# Altium schematic sheet SENSOR_Flash_RAM.SchDoc(SENSOR_Flash_RAM)
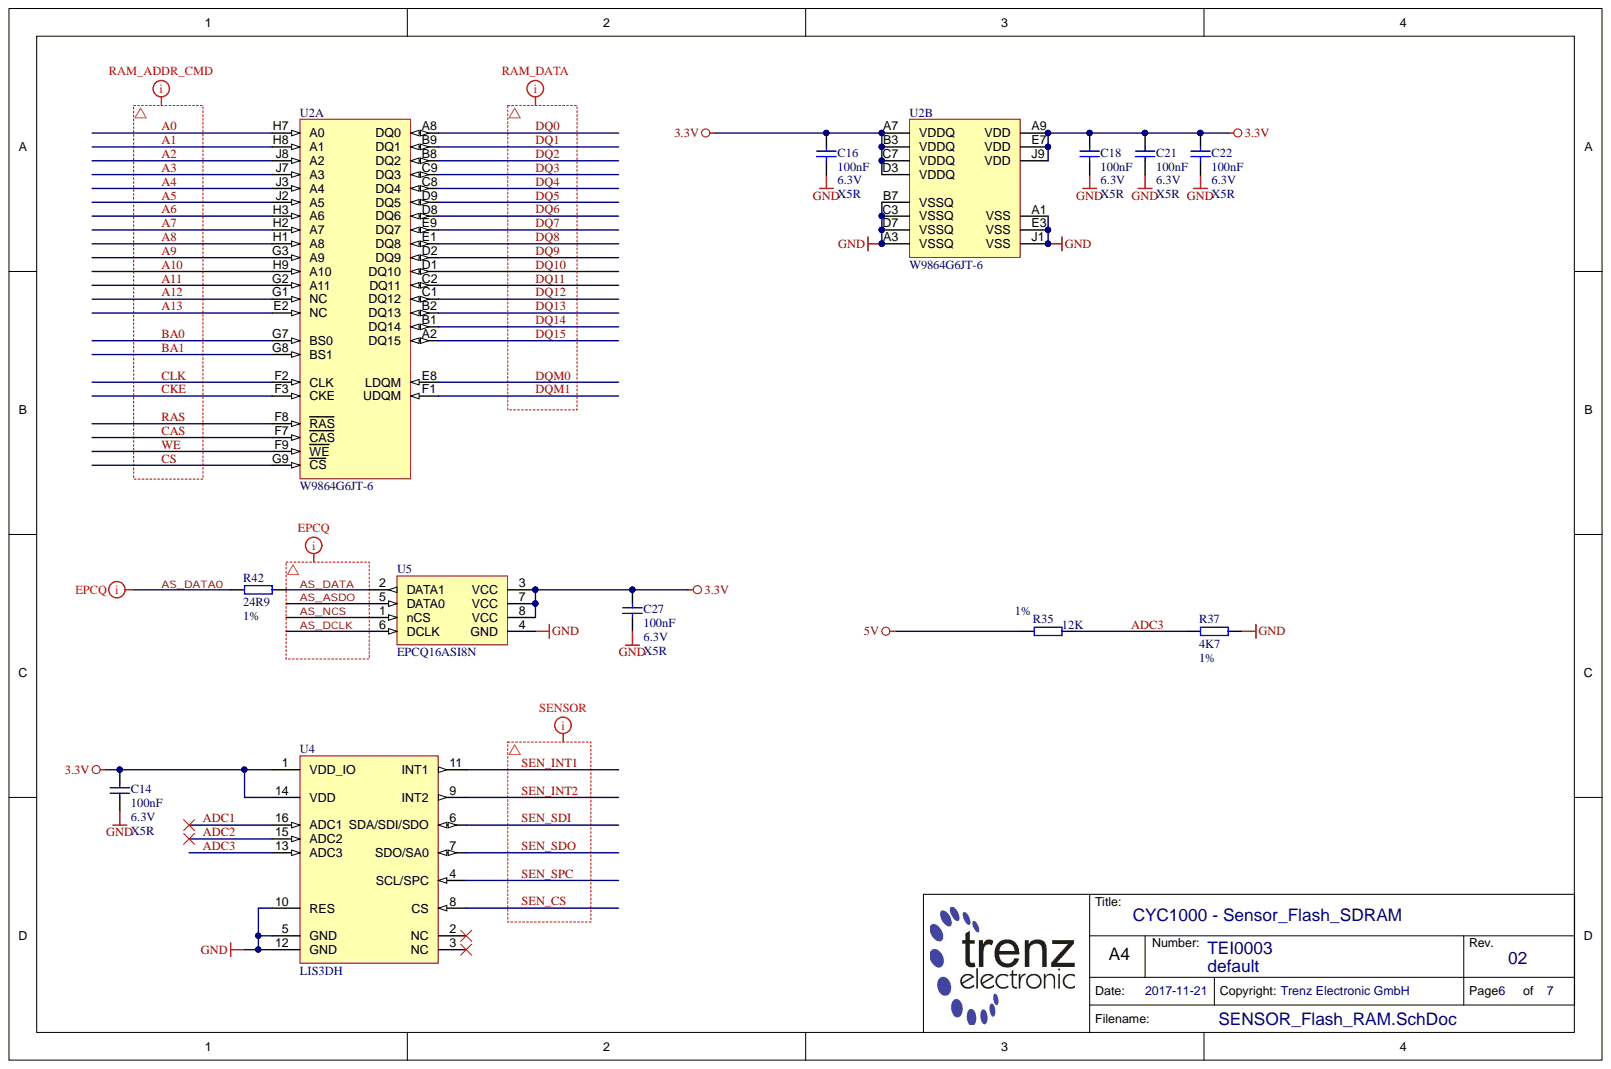
[diagram p0: SENSOR_Flash_RAM.SchDoc]

## Parts
C14  100nF  Technology: X5R  Voltage: 6.3V  [CAPC0603X33N]
C16  100nF  Technology: X5R  Voltage: 6.3V  [CAPC0603X33N]
C18  100nF  Technology: X5R  Voltage: 6.3V  [CAPC0603X33N]
C21  100nF  Technology: X5R  Voltage: 6.3V  [CAPC0603X33N]
C22  100nF  Technology: X5R  Voltage: 6.3V  [CAPC0603X33N]
C27  100nF  Technology: X5R  Voltage: 6.3V  [CAPC0603X33N]
R35  12K  Tolerance: 1%  [RESC1005X40N]
R37  4K7  Tolerance: 1%  [RESC1005X40N]
R42  24R9  Tolerance: 1%  [RESC1005X40N]
U2  W9864G6JT-6  [BGA54C80P9X9_800X800X120]  (parts A,B)
U4  LIS3DH  [PQFN50P300X300X100-16N]
U5  EPCQ16SI8N  [SOIC127P600X175-8N]  (value not drawn)
U5  EPCQ16ASI8N  [SOIC127P600X175-8N]

## Nets
3.3V: C14.1 C16.1 C18.1 C21.1 C22.1 C27.1 U2.A7(VDDQ) U2.A9(VDD) U2.B3(VDDQ) U2.C7(VDDQ) U2.D3(VDDQ) U2.E7(VDD) U2.J9(VDD) U4.1(VDD_IO) U4.14(VDD) U5.3 U5.7 U5.8
5V: R35.1
GND: C14.2 C16.2 C18.2 C21.2 C22.2 C27.2 R37.2 U2.A1(VSS) U2.A3(VSSQ) U2.B7(VSSQ) U2.C3(VSSQ) U2.D7(VSSQ) U2.E3(VSS) U2.J1(VSS) U4.5(GND) U4.10(RES) U4.12(GND) U5.4
A0: U2.H7(A0)
A1: U2.H8(A1)
A2: U2.J8(A2)
A3: U2.J7(A3)
A4: U2.J3(A4)
A5: U2.J2(A5)
A6: U2.H3(A6)
A7: U2.H2(A7)
A8: U2.H1(A8)
A9: U2.G3(A9)
A10: U2.H9(A10)
A11: U2.G2(A11)
A12: U2.G1(NC)
A13: U2.E2(NC)
ADC1: U4.16(ADC1)
ADC2: U4.15(ADC2)
ADC3: R35.2 R37.1 U4.13(ADC3)
AS_ASDO: U5.5
AS_DATA: R42.2 U5.2
AS_DATA0: R42.1
AS_DCLK: U5.6
AS_NCS: U5.1
BA0: U2.G7(BS0)
BA1: U2.G8(BS1)
CAS: U2.F7(CAS)
CKE: U2.F3(CKE)
CLK: U2.F2(CLK)
CS: U2.G9(CS)
DQ0: U2.A8(DQ0)
DQ1: U2.B9(DQ1)
DQ2: U2.B8(DQ2)
DQ3: U2.C9(DQ3)
DQ4: U2.C8(DQ4)
DQ5: U2.D9(DQ5)
DQ6: U2.D8(DQ6)
DQ7: U2.E9(DQ7)
DQ8: U2.E1(DQ8)
DQ9: U2.D2(DQ9)
DQ10: U2.D1(DQ10)
DQ11: U2.C2(DQ11)
DQ12: U2.C1(DQ12)
DQ13: U2.B2(DQ13)
DQ14: U2.B1(DQ14)
DQ15: U2.A2(DQ15)
DQM0: U2.E8(LDQM)
DQM1: U2.F1(UDQM)
RAS: U2.F8(RAS)
SEN_CS: U4.8(CS)
SEN_INT1: U4.11(INT1)
SEN_INT2: U4.9(INT2)
SEN_SDI: U4.6(SDA/SDI/SDO)
SEN_SDO: U4.7(SDO/SA0)
SEN_SPC: U4.4(SCL/SPC)
WE: U2.F9(WE)
N00359:
N00360:
N00361:
N00362:

Not connected: U4 2(NC) 3(NC)

## Library data of the parts
C14 C16 C18 C21 C22 C27 = 24915: KON 100nF 6.3V X5R SMD 10%; Database Table Name: C_0603_0201; Mixed: SMT; Preferred: Yes; Value: 100n; AN: 24915; Case: 0603 (0201); Component Kind: Standard; Library Name: TE.DbLib; Tolerance: 10%
R35 = 22598: WID SMD 12K 1% 0,063W; Database Table Name: R_1005_0402; Mixed: SMT; Preferred: Yes; AN: 22598; Case: 1005 (0402); Component Kind: Standard; Library Name: TE.DbLib; Technology: TK100; Value: 12K
R37 = 22585: WID SMD 4K7 1% 0,063W; Database Table Name: R_1005_0402; Mixed: SMT; Preferred: Yes; Value: 4.7K; AN: 22585; Case: 1005 (0402); Component Kind: Standard; Library Name: TE.DbLib; Technology: TK100
R42 = 23155: WID SMD 24R9 1% 0,063W; Database Table Name: R_1005_0402; Mixed: SMT; Value: 24.9; AN: 23155; Case: 1005 (0402); Component Kind: Standard; Library Name: TE.DbLib; Technology: TK100
U2 = 28045: Database Table Name: SRAM; Mixed: SMT; AN: 28045; Case: TFBGA54; Component Kind: Standard; Description: W9864G6JT-6; H-ART: W9864G6JT-6; Library Name: TE.DbLib; HelpURL: b:\AD\adlib\datasheet\W9864G6JT-6\
U4 = 27979: Database Table Name: SENSOR; Mixed: SMT; AN: 27979; Case: LGA16; Component Kind: Standard; Description: LIS3DH; H-ART: LIS3DH; Library Name: TE.DbLib; HelpURL: b:\AD\adlib\datasheet\ST_LIS3DH\
U5 = 28596: Database Table Name: FLASH; Mixed: SMT; Trenz-Stock: Yes; AN: 28596; Case: SO8; Component Kind: Standard; Description: EPCQ16SI8N; H-ART: EPCQ16SI8N; Library Name: TE.DbLib; HelpURL: b:\AD\adlib\datasheet\EPCQ16SI8N\
U5 = 28753: Database Table Name: FLASH; Mixed: SMT; AN: 28753; Case: SO8; Component Kind: Standard; Description: EPCQ16ASI8N; H-ART: EPCQ16ASI8N; Library Name: TE.DbLib; HelpURL: B:\AD\adlib\datasheet\EPCQA
